# Altium schematic sheet top.SchDoc(top)
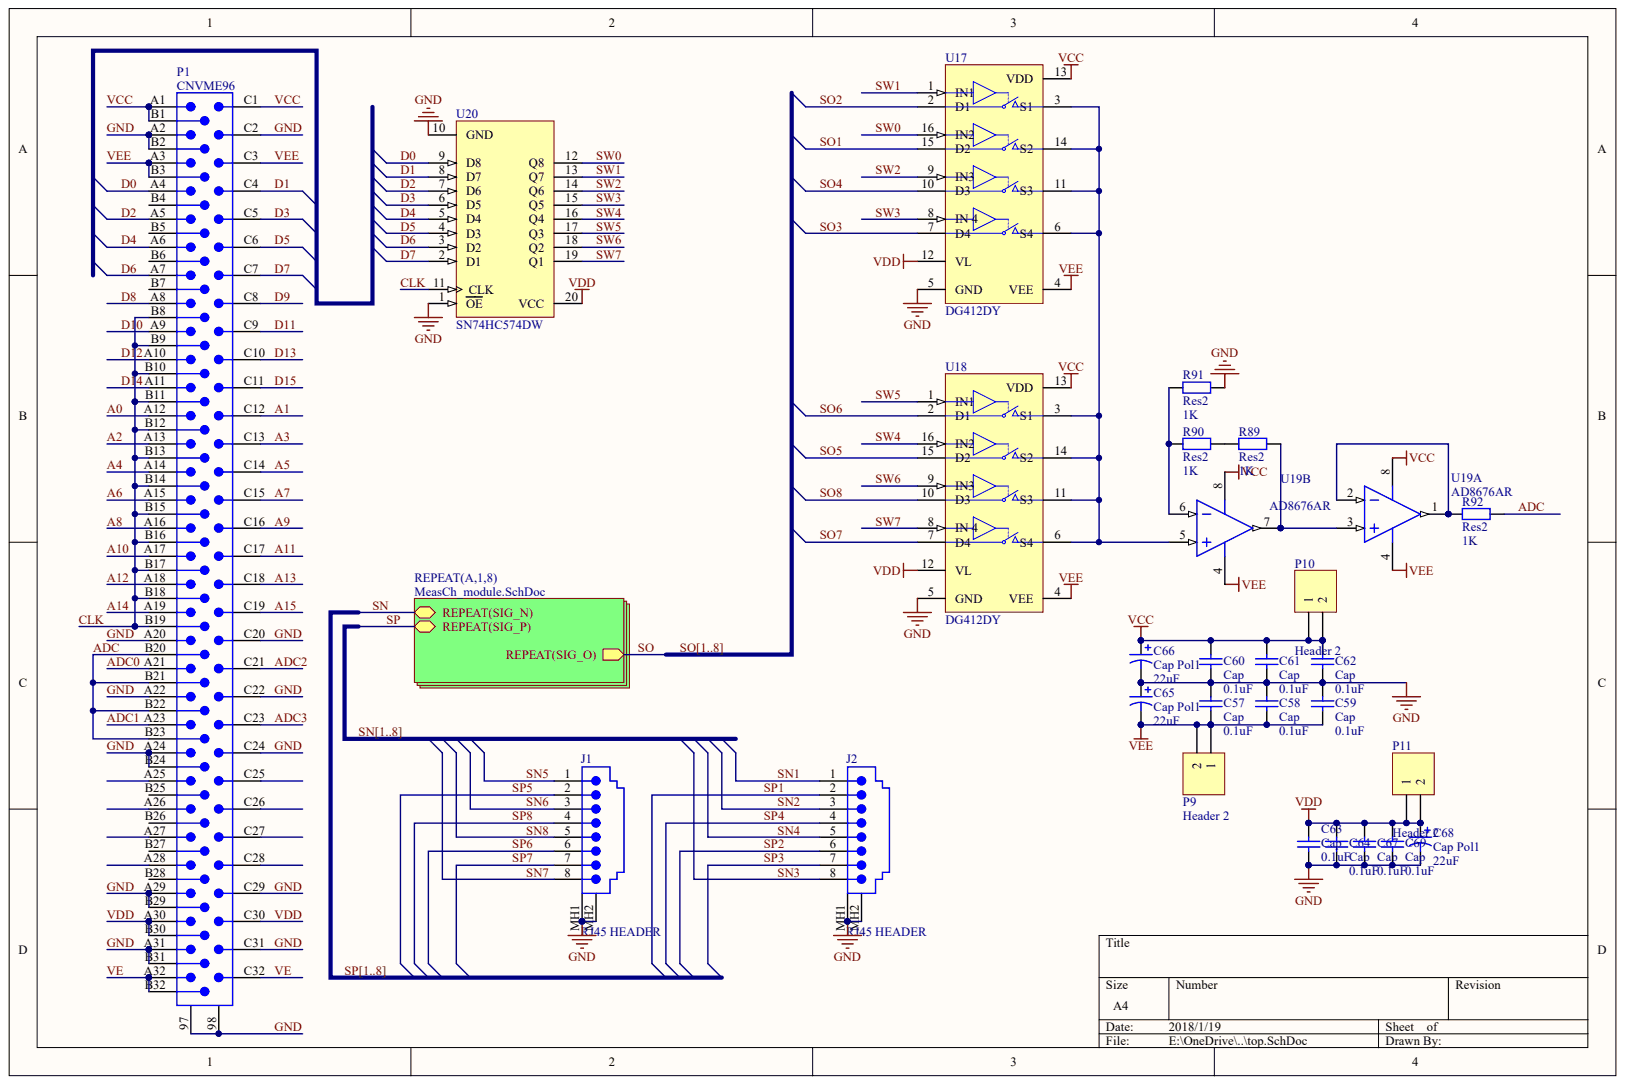
[diagram p0: top.SchDoc]

## Parts
C57  Cap  value 0.1uF
C58  Cap  value 0.1uF
C59  Cap  value 0.1uF
C60  Cap  value 0.1uF
C61  Cap  value 0.1uF
C62  Cap  value 0.1uF
C63  Cap  value 0.1uF
C64  Cap  value 0.1uF
C65  Cap Pol1  value 22uF
C66  Cap Pol1  value 22uF
C67  Cap  value 0.1uF
C68  Cap Pol1  value 22uF
C69  Cap  value 0.1uF
J1  RJ45 HEADER
J2  RJ45 HEADER
P1  CNVME96
P9  Header 2
P10  Header 2
P11  Header 2
R89  Res2  value 1K
R90  Res2  value 1K
R91  Res2  value 1K
R92  Res2  value 1K
U17  DG412DY
U18  DG412DY
U19  AD8676AR  (parts A,B)
U20  SN74HC574DW

## Nets
A0: P1.A12
A1: P1.C12
A2: P1.A13
A3: P1.C13
A4: P1.A14
A5: P1.C14
A6: P1.A15
A7: P1.C15
A8: P1.A16
A9: P1.C16
A10: P1.A17
A11: P1.C17
A12: P1.A18
A13: P1.C18
A14: P1.A19
A15: P1.C19
ADC: P1.B20 P1.B21 P1.B22 P1.B23 R92.2
ADC0: P1.A21
ADC1: P1.A23
ADC2: P1.C21
ADC3: P1.C23
CLK: P1.B8 P1.B9 P1.B10 P1.B11 P1.B12 P1.B13 P1.B14 P1.B15 P1.B16 P1.B17 P1.B18 P1.B19 U20.11(CLK)
D0: P1.A4 U20.9(D8)
D1: P1.C4 U20.8(D7)
D2: P1.A5 U20.7(D6)
D3: P1.C5 U20.6(D5)
D4: P1.A6 U20.5(D4)
D5: P1.C6 U20.4(D3)
D6: P1.A7 U20.3(D2)
D7: P1.C7 U20.2(D1)
D8: P1.A8
D9: P1.C8
D10: P1.A9
D11: P1.C9
D12: P1.A10
D13: P1.C10
D14: P1.A11
D15: P1.C11
GND: C57.2 C58.2 C59.2 C60.1 C61.1 C62.1 C63.1 C64.1 C65.1 C66.2 C67.1 C68.2 C69.1 J1.MH1 J1.MH2 J2.MH1 J2.MH2 P1.97 P1.98 P1.A2 P1.A20 P1.A22 P1.A24 P1.A29 P1.A31 P1.B2 P1.B24 P1.B29 P1.B31 P1.C2 P1.C20 P1.C22 P1.C24 P1.C29 P1.C31 R91.1 U17.5(GND) U18.5(GND) U20.1(OE) U20.10(GND)
SN1: J2.1  [also labelled SN]
SN2: J2.3  [also labelled SN]
SN3: J2.8  [also labelled SN]
SN4: J2.5  [also labelled SN]
SN5: J1.1  [also labelled SN]
SN6: J1.3  [also labelled SN]
SN7: J1.8  [also labelled SN]
SN8: J1.5  [also labelled SN]
SO1: U17.15(D2)  [also labelled SO]
SO2: U17.2(D1)  [also labelled SO]
SO3: U17.7(D4)  [also labelled SO]
SO4: U17.10(D3)  [also labelled SO]
SO5: U18.15(D2)  [also labelled SO]
SO6: U18.2(D1)  [also labelled SO]
SO7: U18.7(D4)  [also labelled SO]
SO8: U18.10(D3)  [also labelled SO]
SP1: J2.2  [also labelled SP]
SP2: J2.6  [also labelled SP]
SP3: J2.7  [also labelled SP]
SP4: J2.4  [also labelled SP]
SP5: J1.2  [also labelled SP]
SP6: J1.6  [also labelled SP]
SP7: J1.7  [also labelled SP]
SP8: J1.4  [also labelled SP]
SW0: U17.16(IN2) U20.12(Q8)
SW1: U17.1(IN1) U20.13(Q7)
SW2: U17.9(IN3) U20.14(Q6)
SW3: U17.8(IN_4) U20.15(Q5)
SW4: U18.16(IN2) U20.16(Q4)
SW5: U18.1(IN1) U20.17(Q3)
SW6: U18.9(IN3) U20.18(Q2)
SW7: U18.8(IN_4) U20.19(Q1)
VCC: C60.2 C61.2 C62.2 C66.1 P1.A1 P1.B1 P1.C1 P10.1 P10.2 U17.13(VDD) U18.13(VDD) U19.8
VDD: C63.2 C64.2 C67.2 C68.1 C69.2 P1.A30 P1.B30 P1.C30 P11.1 P11.2 U17.12(VL) U18.12(VL) U20.20(VCC)
VE: P1.A32 P1.B32 P1.C32
VEE: C57.1 C58.1 C59.1 C65.2 P1.A3 P1.B3 P1.C3 P9.1 P9.2 U17.4(VEE) U18.4(VEE) U19.4
NetP1_A25: P1.A25
NetP1_A26: P1.A26
NetP1_A27: P1.A27
NetP1_A28: P1.A28
NetP1_B4: P1.B4
NetP1_B5: P1.B5
NetP1_B6: P1.B6
NetP1_B7: P1.B7
NetP1_B25: P1.B25
NetP1_B26: P1.B26
NetP1_B27: P1.B27
NetP1_B28: P1.B28
NetP1_C25: P1.C25
NetP1_C26: P1.C26
NetP1_C27: P1.C27
NetP1_C28: P1.C28
NetR89_1: R89.1 R90.2
NetR89_2: R89.2 U19.3 U19.7
NetR90_1: R90.1 R91.2 U19.6
NetR92_1: R92.1 U19.1 U19.2
NetU17_3: U17.3(S1) U17.6(S4) U17.11(S3) U17.14(S2) U18.3(S1) U18.6(S4) U18.11(S3) U18.14(S2) U19.5
N00213:

## Library data of the parts
P1 = (no symbol name): Text Field6: Alternate Layout Available; Text Field7: Published 14-Feb-2002
R91 R92 = (no symbol name): Value: 1K; Published: 8-Jun-2000; LatestRevisionDate: 17-Jul-2002; LatestRevisionNote: Re-released for DXP Platform.; PackageReference: AXIAL-0.4; PackageDescription: Axial Device, Thru-Hole; 2 Leads; 0.4 in Pin Spacing; Publisher: Altium Limited
C57-C69 J1 J2 P9-P11 R89 R90 U17-U20 = (no symbol name)
  C57-C64 C67 C69: Published: 8-Jun-2000; LatestRevisionDate: 17-Jul-2002; LatestRevisionNote: Re-released for DXP Platform.; PackageReference: RAD-0.3; PackageDescription: Radial Cap, Thru-Hole; 2 Leads; 0.3 in Pin Spacing
  C65 C66 C68: Published: 8-Jun-2000; LatestRevisionDate: 17-Jul-2002; LatestRevisionNote: Re-released for DXP Platform.; PackageReference: RB7.6-15; PackageDescription: Polarized Capacitor (Radial); 2 Leads
  J1 J2: Design Item Id: CON-RA-RJ45-95122-2881
  P9-P11: LatestRevisionDate: 17-Jul-2002; LatestRevisionNote: Re-released for DXP Platform.
  R89 R90: Published: 8-Jun-2000; LatestRevisionDate: 17-Jul-2002; LatestRevisionNote: Re-released for DXP Platform.; PackageReference: AXIAL-0.4; PackageDescription: Axial Device, Thru-Hole; 2 Leads; 0.4 in Pin Spacing
  U17 U18: Published: 16-Jan-2001; LatestRevisionDate: 13-Apr-2006; LatestRevisionNote: IPC-7351 Footprint Added.; DatasheetVersion: Aug-1995; PackageReference: NSO16; PackageDescription: 16-Pin Small Outline Integrated Circuit 1.27 mm Pitch; Code_JEDEC: MS-012-AC; Code_IPC: SOIC127P600-16; ComponentLink1Description: Manufacturer Link; ComponentLink1URL: http://www.maxim-ic.com/; ComponentLink2Description: Datasheet; ComponentLink2URL: http://pdfserv.maxim-ic.com/en/ds/DG411-DG413.pdf
  U19: Published: 8-Jul-2005; LatestRevisionDate: 13-Apr-2006; LatestRevisionNote: IPC-7351 Footprint Added.; DatasheetVersion: Rev. C 2001; PackageVersion: revA; PackageReference: SO-8; PackageDescription: 8-Pin Small Outline Integrated Circuit 1.27 mm Pitch; Code_JEDEC: MS-012-AA; Code_IPC: SOIC127P600-8; ComponentLink1Description: Manufacturer Link; ComponentLink1URL: http://www.analog.com/en/prod/0,,759_786_AD8062%2C00.html; ComponentLink2Description: Datasheet; ComponentLink2URL: http://www.analog.com/UploadedFiles/Data_Sheets/244863930AD8061_2_3_c.pdf
  U20: Published: 8-Jun-2000; LatestRevisionDate: 13-Apr-2006; LatestRevisionNote: IPC-7351 Footprint Added.; DatasheetVersion: Jan-1998; PackageVersion: Mar-2000; PackageReference: DW020; PackageDescription: 20-Pin Plastic Small Outline Integrated Circuit 1.27 mm Pitch; Code_JEDEC: MS-013; Code_IPC: SOIC127P1030-20; ComponentLink1Description: Manufacturer Link; ComponentLink1URL: http://www.ti.com/; ComponentLink2Description: Datasheet; ComponentLink2URL: http://www-s.ti.com/sc/ds/sn74hc574.pdf
  C57-C69 P9-P11 R89 R90 U17-U20: Publisher: Altium Limited
